# Altium schematic sheet Power_Sheet.SchDoc
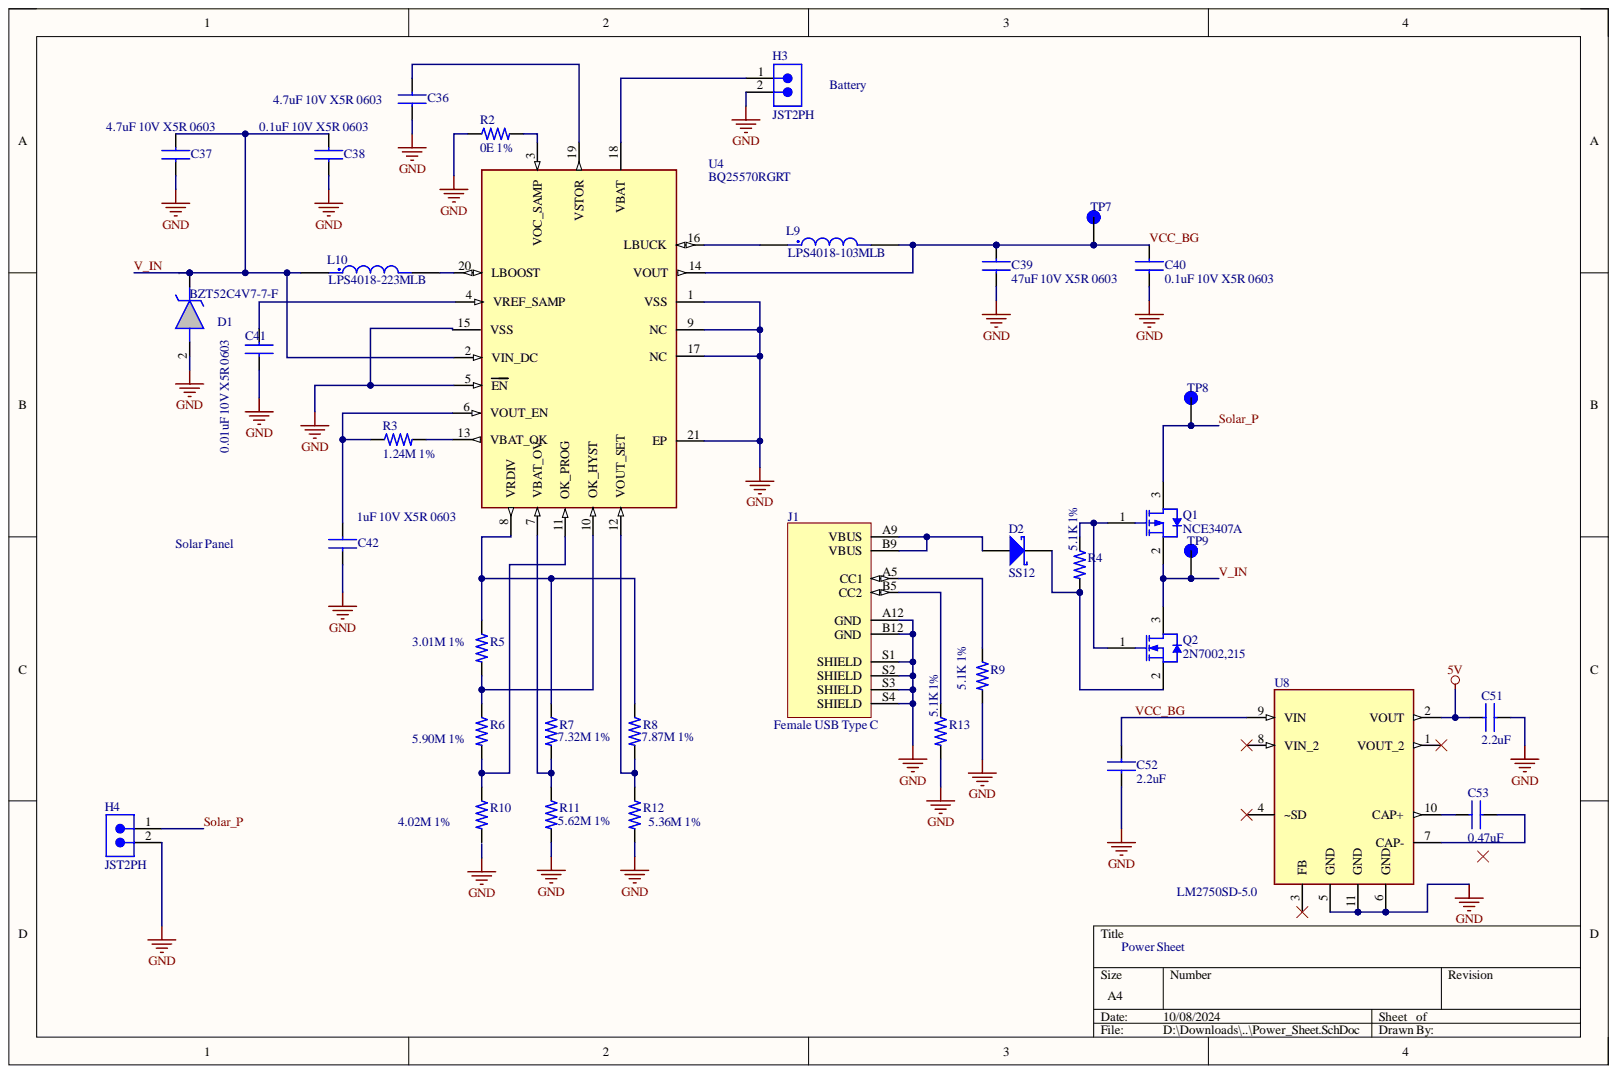
[diagram p0: Power_Sheet.SchDoc]

## Parts
C36  4.7uF 10V X5R 0603  Case Code - in: 0603  [CAP_0603_1MM]
C37  4.7uF 10V X5R 0603  Case Code - in: 0603  Dielectric: X5R  [CAP_0603_1MM]
C38  0.1uF 10V X5R 0603  Case Code - in: 0603  Dielectric: X5R  [CAP_0603_1MM]
C39  47uF 10V X5R 0603  [CAP_0603_1MM]
C40  0.1uF 10V X5R 0603  Case Code - in: 0603  [CAP_0603_1MM]
C41  0.01uF 10V X5R 0603  Case Code - in: 0603  Dielectric: X5R  [CAP_0603_1MM]
C42  1uF 10V X5R 0603  [CAP_0603_1MM]
C51  2.2uF  [CAP_0603_1MM]
C52  2.2uF  [CAP_0603_1MM]
C53  0.47uF  [CAP_0603_1MM]
D1  BZT52C4V7-7-F  [SOD3716X145N]
D2  SS12  [FAIR-DO-214AC-2_V]
H3  JST2PH  [JST_B2B-PH-K-S(LF)(SN)]
H4  JST2PH  [JST_B2B-PH-K-S(LF)(SN)]
J1  Female USB Type C  [GCT_USB4125-GF-A_REVA2]
L9  LPS4018-103MLB  [IND_LPS4018-223MRC]
L10  LPS4018-223MLB  [IND_LPS4018-223MRC]
Q1  NCE3407A  [NCEP-SOT23-3_M]
Q2  2N7002,215  [NXP-SOT23-3_V]
R2  0E 1%  Tolerance: 1%  [RES_0603_1608X06]
R3  1.24M 1%  Tolerance: 1%  [RES_0603_1608X06]
R4  5.1K 1%  Tolerance: 1%  [RES_0603_1608X06]
R5  3.01M 1%  Tolerance: 1%  [RES_0603_1608X06]
R6  5.90M 1%  Tolerance: 1%  [RES_0603_1608X06]
R7  7.32M 1%  [RES_0603_1608X06]
R8  7.87M 1%  Tolerance: 1%  [RES_0603_1608X06]
R9  5.1K 1%  Tolerance: 1%  [RES_0603_1608X06]
R10  4.02M 1%  Tolerance: 1%  [RES_0603_1608X06]
R11  5.62M 1%  [RES_0603_1608X06]
R12  5.36M 1%  Tolerance: 1%  [RES_0603_1608X06]
R13  5.1K 1%  Tolerance: 1%  [RES_0603_1608X06]
TP7  Test_point  [TP]  (value not drawn)
TP8  Test_point  [TP]  (value not drawn)
TP9  Test_point  [TP]  (value not drawn)
U4  BQ25570RGRT  [QFN50P350X350X100-21N]
U8  LM2750SD-5.0  [LM2750_DFN300X300X80-11N]

## Nets
5V: C51.2 U8.2(VOUT)
GND: C36.1 C37.1 C38.1 C39.1 C40.1 C41.1 C42.1 C51.1 C52.1 D1.2 H3.2 H4.2 J1.A12(GND) J1.B12(GND) J1.S1(SHIELD) J1.S2(SHIELD) J1.S3(SHIELD) J1.S4(SHIELD) R2.1 R9.2 R11.2 R12.2 R13.2 U4.1(VSS) U4.5(EN) U4.9(NC) U4.15(VSS) U4.17(NC) U4.21(EP) U8.5(GND) U8.6(GND) U8.11(GND)
Solar_P: H4.1 Q1.3 TP8.TP
V_IN: C37.2 C38.2 D1.1 L10.1 Q1.2 Q2.3 TP9.TP U4.2(VIN_DC)
VCC_BG: C39.2 C40.2 C52.2 L9.2 TP7.TP U4.14(VOUT) U8.9(VIN)
NetC36_2: C36.2 U4.19(VSTOR)
NetC41_2: C41.2 U4.4(VREF_SAMP)
NetC42_2: C42.2 R3.1 U4.6(VOUT_EN)
NetC53_1: C53.1 U8.7(CAP-)
NetC53_2: C53.2 U8.10(CAP+)
NetD2_1: D2.1 J1.A9(VBUS) J1.B9(VBUS)
NetD2_2: D2.2 Q2.2 R4.2
NetH3_1: H3.1 U4.18(VBAT)
NetJ1_A5: J1.A5(CC1) R9.1
NetJ1_B5: J1.B5(CC2) R13.1
NetL9_1: L9.1 U4.16(LBUCK)
NetL10_2: L10.2 U4.20(LBOOST)
NetQ1_1: Q1.1 Q2.1 R4.1
NetR2_2: R2.2 U4.3(VOC_SAMP)
NetR3_2: R3.2 U4.13(VBAT_OK)
NetR5_1: R5.1 R7.1 R8.1 U4.8(VRDIV)
NetR5_2: R5.2 R6.1 U4.10(OK_HYST)
NetR6_2: R6.2 R10.1 U4.11(OK_PROG)
NetR7_2: R7.2 R11.1 U4.7(VBAT_OV)
NetR8_2: R8.2 R12.1 U4.12(VOUT_SET)

Not connected: R10 2; U8 1(VOUT_2) 3(FB) 4(~SD) 8(VIN_2)

## Library data of the parts
C36-C42 C52 C53 = GRM188R61A226ME15D: CAP CER 22UF 10V X5R 0603; Manufacturer: Murata; Brand: Murata Electronics; Tolerance: 20 %; Type: Voltage and Temperature Derating Recommended Product; Unit Weight: 0.000222 oz; Voltage Rating DC: 10 VDC; Capacitance: 22 uF; Case Code - mm: 1608; Component Kind: Standard; ComponentLink1Description: http://www.farnell.com/datasheets/2047978.pdf?src-supplier=Element14; ComponentLink1URL: http://www.farnell.com/datasheets/2047978.pdf?src-supplier=Element14; Factory Pack Quantity: 4000; Height: 0.8 mm; Length: 1.6 mm; Library Name: LPEDT_Parts.IntLib; Manufacturer Part Number: GRM188R61A226ME15D; Maximum Operating Temperature: + 85 C; Minimum Operating Temperature: - 55 C; Package / Case: 0603 (1608 metric); Packaging: Reel; Pricing 1: 1=0.33, 10=0.226, 50=0.1506, 100=0.1279, 500=0.0903, 1000=0.079 (USD); Product: General Type MLCCs; Product Category: Multilayer Ceramic Capacitors MLCC - SMD/SMT; RoHS: True; Series: GRM; Stock 1: 849458; Supplier 1: Digi-Key; Supplier Part Number 1: 490-10476-1-ND; Termination Style: SMD/SMT; Width: 0.8 mm
  C36 C40: Dielectric: X5R
  C39 C42 C52 C53: Case Code - in: 0603; Dielectric: X5R
C51 = GRM188R61A226ME15D: Manufacturer: Murata; Brand: Murata Electronics; Capacitance: 22 uF; Dielectric: X5R; Tolerance: 20 %; Type: Voltage and Temperature Derating Recommended Product; Unit Weight: 0.000222 oz; Voltage Rating DC: 10 VDC; Case Code - in: 0603; Case Code - mm: 1608; Component Kind: Standard; ComponentLink1Description: http://www.farnell.com/datasheets/2047978.pdf?src-supplier=Element14; ComponentLink1URL: http://www.farnell.com/datasheets/2047978.pdf?src-supplier=Element14; Description: 2.2uF; Factory Pack Quantity: 4000; Height: 0.8 mm; Length: 1.6 mm; Library Name: LPEDT_Parts.IntLib; Manufacturer Part Number: GRM188R61A226ME15D; Maximum Operating Temperature: + 85 C; Minimum Operating Temperature: - 55 C; Package / Case: 0603 (1608 metric); Packaging: Reel; Pricing 1: 1=0.33, 10=0.226, 50=0.1506, 100=0.1279, 500=0.0903, 1000=0.079 (USD); Product: General Type MLCCs; Product Category: Multilayer Ceramic Capacitors MLCC - SMD/SMT; RoHS: True; Series: GRM; Stock 1: 849458; Supplier 1: Digi-Key; Supplier Part Number 1: 490-10476-1-ND; Termination Style: SMD/SMT; Width: 0.8 mm
D1 = BZT52C4V7-7-F: Diodes Inc BZT52C4V7-7-F Zener Diode, 4.7V 7% 500 mW SMT 2-Pin SOD-123; Manufacturer_Name: Diodes Incorporated; Geometry.Height: 1.45mm; Arrow Part Number: BZT52C4V7-7-F; Arrow Price/Stock: https://www.arrow.com/en/products/bzt52c4v7-7-f/diodes-incorporated?region=nac; Component Kind: Standard; Datasheet Link: https://www.diodes.com/assets/Datasheets/ds18004.pdf; Library Name: BZT52C4V7-7-F.SchLib; Manufacturer_Part_Number: BZT52C4V7-7-F; Mouser Part Number: 621-BZT52C4V7-F; Mouser Price/Stock: https://www.mouser.co.uk/ProductDetail/Diodes-Incorporated/BZT52C4V7-7-F?qs=J8U5V1LGimN8O12FVAOKUQ%3D%3D
D2 = CMP-004-00016-2: Schottky Rectifier, 20 V, 1 A, -65 to 125 degC, 2-Pin DO-214AC, RoHS, Tape and Reel; Manufacturer: Fairchild; Forward Voltage: 500mV; Max Surge Current: 40A; Peak Reverse Current: 200uA; Case/Package: SMA; Component Kind: Standard; Datasheet URL: https://www.fairchildsemi.com/datasheets/SS/SS12.pdf; Datasheet Version: Rev. 1.9, 01/2015; Manufacturer URL: https://www.fairchildsemi.com/; Max Operating Temperature: 125°C; Min Operating Temperature: -65°C; Mounting Technology: SM; Package Description: 2-Pin Surface Mount Device, Body 4.375 x 2.725 mm; Package Quantity: 7500; Package Reference: DO-214AC-2; Package URL: https://www.fairchildsemi.com/package-drawings/DO/DO214AC.pdf; Packaging: TapeandReel; Pins: 2; RoHS Compliant: True; Library Name: University of Colorado Boulder
H3 H4 = JST2PH: Connector Header Through Hole 2 position 0.079" (2.00mm); Manufacturer: JST; Manufacturer Part Number: B2B-PH-K-S; Category: Connectors; Component Kind: Standard; ComponentLink1Description: https://datasheet.ciiva.com/6905/phseries-6905929.pdf?src-supplier=Digi-Key; ComponentLink1URL: https://datasheet.ciiva.com/6905/phseries-6905929.pdf?src-supplier=Digi-Key; Library Name: Connectors.IntLib; Pricing: 1=0.19, 10=0.147, 100=0.1088, 500=0.09006, 1000=0.0788, 5000=0.06754, 10000=0.06567 (USD); RoHS: Yes; Stock: 1077617; Supplier: Digi-Key; Supplier Part Number: 455-1704-ND
J1 = USB-C Power Connector: USB-C (USB TYPE-C) Receptacle Connector 24 (6+18 Dummy) Position Surface Mount, Right Angle; Through Hole; Manufacturer Part Number: USB4125-GF-A_REVA2; Availability: Unavailable; Component Kind: Standard; Library Name: Connectors.IntLib; Package: None; Price: None
L9 L10 = LPS4018-223MRC: 22 µH Shielded Drum Core Inductor 650 mA 360mOhm Max Nonstandard; MF: Coilcraft; Check_prices: https://www.snapeda.com/parts/LPS4018-223MRC/Coilcraft/view-part/?ref=eda; Component Kind: Standard; Library Name: LPS4018-223MRC.IntLib; MP: LPS4018-223MRC; Package: None; SnapEDA_Link: https://www.snapeda.com/parts/LPS4018-223MRC/Coilcraft/view-part/?ref=snap
Q1 = CMP-013-00018-3: P-Channel Enhancement Mode Power MOSFET, 30 V, 4.3 A, - 55 to 150 degC, 3-Pin SOT23, RoHS, Tape and Reel; Manufacturer: NCE Power; Case/Package: SOT23-3; Component Kind: Standard; Datasheet URL: http://www.ncepower.com/Upload/MOSFET/mos1/55vpmos/NCE3407Adatasheet-17275285683.pdf; Datasheet Version: Rev. 1.1, 07/2014; Mounting Technology: SM; Package Description: 3-Pin Small Outline Transistor, Body 2.9 x 1.3 mm, Pitch 0.95 mm; Package Reference: SOT23-3; RoHS Compliant: Unknown; Library Name: University of Colorado Boulder
Q2 = CMP-013-00011-4: N-channel Trench MOSFET, 60 V, 300 mA, -65 to 150 degC, 3-Pin SOT-23 (TO-236AB), RoHS, Tape and Reel; Manufacturer: NXP Semiconductors; Elements: 1; Input Capacitance: 50pF; Max Dual Supply Voltage: 60V; Nominal Vgs: 2V; Package Version: Feb-16; Power: 830mW; Rds On Max: 5Ohm; Case/Package: TO-236-3; Component Kind: Standard; Datasheet URL: http://www.nxp.com/documents/data_sheet/2N7002.pdf; Datasheet Version: Rev. 7, 09/2011; Max Operating Temperature: 150°C; Min Operating Temperature: -65°C; Mounting Technology: SM; Nominal Dual Supply Voltage: 60V; Package Description: 3-Pin Small Outline Transistor, Body 1.3 x 2.9 mm, Pitch 0.95 mm; Package Quantity: 1; Package Reference: SOT23-3; Packaging: TapeandReel; Pins: 8; RoHS Compliant: True; Vds Max: 60V; Library Name: University of Colorado Boulder
R2 R3 R5-R12 = RC0603FR-07316KL: SMD Chip Resistor, 316 kOhm, ± 1%, 100 mW, 0603 [1608 Metric], Thick Film, General Purpose; Manufacturer: Yageo; Max Power Dissipation: 100mW; Temperature Coefficient: 100ppm/°C; Value: 316kR; Voltage Rating: 50V; Case Code (Imperial): 0603; Case Code (Metric): 1608; Case/Package: 0603; Category: Chip SMD Resistors; Component Kind: Standard; ComponentLink1Description: https://datasheet.ciiva.com/2454/pyu-r-int-thick-7-2454966.pdf?src-supplier=Digikey; ComponentLink1URL: https://datasheet.ciiva.com/2454/pyu-r-int-thick-7-2454966.pdf?src-supplier=Digikey; ComponentLink2Description: https://datasheet.ciiva.com/2454/pyu-r-int-thick-7-2454967.pdf?src-supplier=Digikey; ComponentLink2URL: https://datasheet.ciiva.com/2454/pyu-r-int-thick-7-2454967.pdf?src-supplier=Digikey; ComponentLink3Description: https://datasheet.ciiva.com/2454/pyu-r-int-thick-7-2454968.pdf?src-supplier=Digikey; ComponentLink3URL: https://datasheet.ciiva.com/2454/pyu-r-int-thick-7-2454968.pdf?src-supplier=Digikey; Features: Moisture Resistant; Lead Free: Lead Free; Library Name: LPEDT_Parts.IntLib; Manufacturer Part Number: RC0603FR-07316KL; Max Operating Temperature: 155°C; Min Operating Temperature: -55°C; Mounting Technology: Surface Mount; Number of Terminations: 2; Packaging: Tape and Reel; Power Rating: 100mW; Pricing: 1=0.1, 10=0.019, 50=0.0112, 100=0.0093, 500=0.00622, 1000=0.00532 (USD); RoHS: Yes; RoHS Compliant: Yes; Series: RC; Stock: 149463; Supplier: Digikey; Supplier Part Number: 311-316KHRCT-ND; Termination: SMD/SMT; Voltage Rating (DC): 50V
  R7 R11: Tolerance: 1%
R4 R13 = RC0603FR-07316KL: SMD Chip Resistor, 5.1KOhm, ± 1%, 100 mW, 0603 [1608 Metric], Thick Film, General Purpose; Manufacturer: Yageo; Max Power Dissipation: 100mW; Temperature Coefficient: 100ppm/°C; Value: 316kR; Voltage Rating: 50V; Case Code (Imperial): 0603; Case Code (Metric): 1608; Case/Package: 0603; Category: Chip SMD Resistors; Component Kind: Standard; ComponentLink1Description: https://datasheet.ciiva.com/2454/pyu-r-int-thick-7-2454966.pdf?src-supplier=Digikey; ComponentLink1URL: https://datasheet.ciiva.com/2454/pyu-r-int-thick-7-2454966.pdf?src-supplier=Digikey; ComponentLink2Description: https://datasheet.ciiva.com/2454/pyu-r-int-thick-7-2454967.pdf?src-supplier=Digikey; ComponentLink2URL: https://datasheet.ciiva.com/2454/pyu-r-int-thick-7-2454967.pdf?src-supplier=Digikey; ComponentLink3Description: https://datasheet.ciiva.com/2454/pyu-r-int-thick-7-2454968.pdf?src-supplier=Digikey; ComponentLink3URL: https://datasheet.ciiva.com/2454/pyu-r-int-thick-7-2454968.pdf?src-supplier=Digikey; Features: Moisture Resistant; Lead Free: Lead Free; Library Name: LPEDT_Parts.IntLib; Manufacturer Part Number: RC0603FR-07316KL; Max Operating Temperature: 155°C; Min Operating Temperature: -55°C; Mounting Technology: Surface Mount; Number of Terminations: 2; Packaging: Tape and Reel; Power Rating: 100mW; Pricing: 1=0.1, 10=0.019, 50=0.0112, 100=0.0093, 500=0.00622, 1000=0.00532 (USD); RoHS: Yes; RoHS Compliant: Yes; Series: RC; Stock: 149463; Supplier: Digikey; Supplier Part Number: 311-316KHRCT-ND; Termination: SMD/SMT; Voltage Rating (DC): 50V
TP7-TP9 = Test_point: SMT Test Point- Mininature-1000 pc Reels; MF: Keystone Electronics; MP: 5019; Availability: In Stock; Component Kind: Standard; Package: None; Price: None; Purchase-URL: https://pricing.snapeda.com/search/part/5019/?ref=eda; Library Name: Project_Vega.IntLib
U4 = BQ25570RGRT: Ultra Low power Harvester power Management IC with boost charger, and Nanopower Buck Converter 20-VQFN -40 to 125; MF: Texas Instruments; Availability: Warning; Check_prices: https://www.snapeda.com/parts/BQ25570RGRT/Texas%20Instruments/view-part/49255/?ref=eda; Component Kind: Standard; MP: BQ25570RGRT; Package: VQFN-20 Texas Instruments; Price: None; Purchase-URL: https://pricing.snapeda.com/search/part/BQ25570RGRT/?ref=eda; SnapEDA_Link: https://www.snapeda.com/parts/BQ25570RGRT/Texas%20Instruments/view-part/49255/?ref=snap; Supplier 1: Digikey; Supplier Part Number 1: 296-37014-1-ND; Library Name: Project_Vega.IntLib
U8 = LM2750SD-5.0: IC CONV REG SW CAP 5V; MP: LM2750SD-5-0; Availability: Unavailable; Component Kind: Standard; Package: None; Price: None; Supplier 1: Digikey; Supplier Part Number 1: LM2750SD-5.0/NOPBCT-ND; Library Name: Project_Vega.IntLib
